# Altium schematic sheet Matching_Capacitors.SchDoc(Matching_Capacitors)
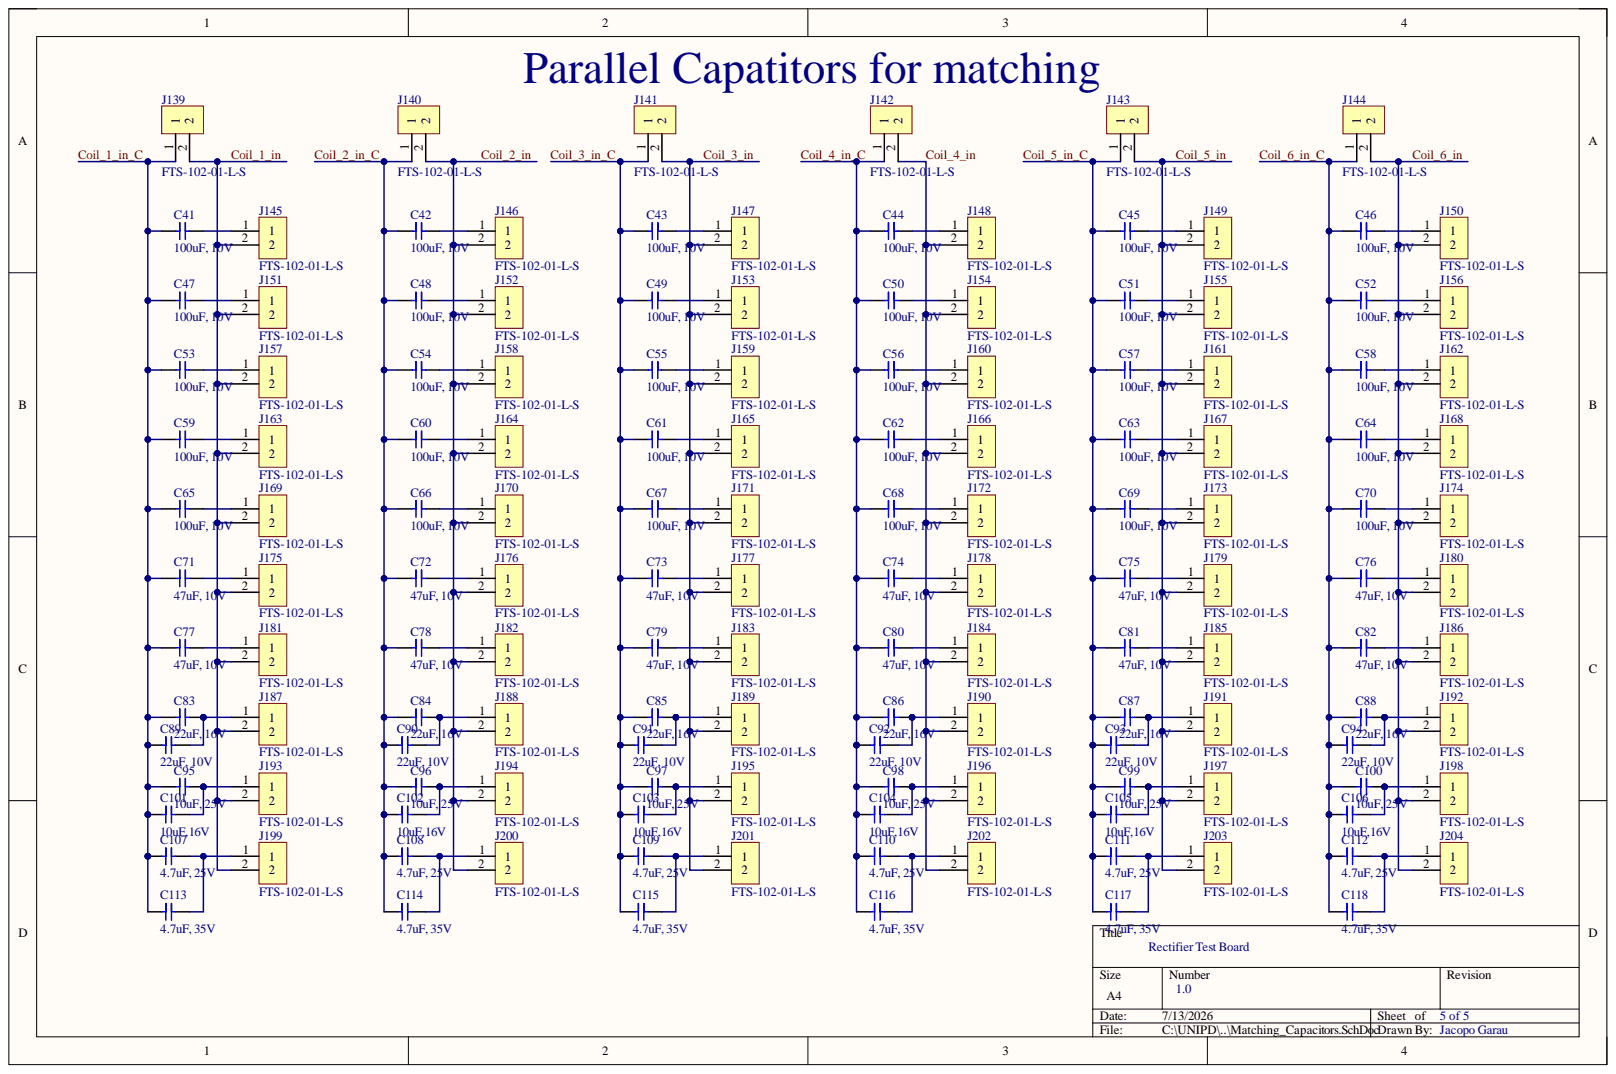
[diagram p0: Matching_Capacitors.SchDoc]

## Parts
C41  100uF, 10V  [CAP_1206]
C42  100uF, 10V  [CAP_1206]
C43  100uF, 10V  [CAP_1206]
C44  100uF, 10V  [CAP_1206]
C45  100uF, 10V  [CAP_1206]
C46  100uF, 10V  [CAP_1206]
C47  100uF, 10V  [CAP_1206]
C48  100uF, 10V  [CAP_1206]
C49  100uF, 10V  [CAP_1206]
C50  100uF, 10V  [CAP_1206]
C51  100uF, 10V  [CAP_1206]
C52  100uF, 10V  [CAP_1206]
C53  100uF, 10V  [CAP_1206]
C54  100uF, 10V  [CAP_1206]
C55  100uF, 10V  [CAP_1206]
C56  100uF, 10V  [CAP_1206]
C57  100uF, 10V  [CAP_1206]
C58  100uF, 10V  [CAP_1206]
C59  100uF, 10V  [CAP_1206]
C60  100uF, 10V  [CAP_1206]
C61  100uF, 10V  [CAP_1206]
C62  100uF, 10V  [CAP_1206]
C63  100uF, 10V  [CAP_1206]
C64  100uF, 10V  [CAP_1206]
C65  100uF, 10V  [CAP_1206]
C66  100uF, 10V  [CAP_1206]
C67  100uF, 10V  [CAP_1206]
C68  100uF, 10V  [CAP_1206]
C69  100uF, 10V  [CAP_1206]
C70  100uF, 10V  [CAP_1206]
C71  47uF, 10V  Voltage: 10V  [CAP_1206]
C72  47uF, 10V  Voltage: 10V  [CAP_1206]
C73  47uF, 10V  Voltage: 10V  [CAP_1206]
C74  47uF, 10V  Voltage: 10V  [CAP_1206]
C75  47uF, 10V  Voltage: 10V  [CAP_1206]
C76  47uF, 10V  Voltage: 10V  [CAP_1206]
C77  47uF, 10V  Voltage: 10V  [CAP_1206]
C78  47uF, 10V  Voltage: 10V  [CAP_1206]
C79  47uF, 10V  Voltage: 10V  [CAP_1206]
C80  47uF, 10V  Voltage: 10V  [CAP_1206]
C81  47uF, 10V  Voltage: 10V  [CAP_1206]
C82  47uF, 10V  Voltage: 10V  [CAP_1206]
C83  22uF, 16V  Voltage: 16V  [CAP_1206]
C84  22uF, 16V  Voltage: 16V  [CAP_1206]
C85  22uF, 16V  Voltage: 16V  [CAP_1206]
C86  Voltage: 16V  [CAP_1206]  (hidden comment: 22uF, 16V)
C87  22uF, 16V  Voltage: 16V  [CAP_1206]
C88  22uF, 16V  Voltage: 16V  [CAP_1206]
C89  22uF, 10V  Voltage Rating: 10V  [CAP_0805]
C90  22uF, 10V  Voltage Rating: 10V  [CAP_0805]
C91  22uF, 10V  Voltage Rating: 10V  [CAP_0805]
C92  22uF, 10V  Voltage Rating: 10V  [CAP_0805]
C93  22uF, 10V  Voltage Rating: 10V  [CAP_0805]
C94  22uF, 10V  Voltage Rating: 10V  [CAP_0805]
C95  10uF, 25V  Voltage: 25V  [CAP_1206]
C96  10uF, 25V  Voltage: 25V  [CAP_1206]
C97  10uF, 25V  Voltage: 25V  [CAP_1206]
C98  10uF, 25V  Voltage: 25V  [CAP_1206]
C99  10uF, 25V  Voltage: 25V  [CAP_1206]
C100  10uF, 25V  Voltage: 25V  [CAP_1206]
C101  10uF,16V  [CAP_0805]
C102  10uF,16V  [CAP_0805]
C103  10uF,16V  [CAP_0805]
C104  10uF,16V  [CAP_0805]
C105  10uF,16V  [CAP_0805]
C106  10uF,16V  [CAP_0805]
C107  4.7uF, 25V  Voltage: 25V  [CAP_1206]
C108  4.7uF, 25V  Voltage: 25V  [CAP_1206]
C109  4.7uF, 25V  Voltage: 25V  [CAP_1206]
C110  4.7uF, 25V  Voltage: 25V  [CAP_1206]
C111  4.7uF, 25V  Voltage: 25V  [CAP_1206]
C112  4.7uF, 25V  Voltage: 25V  [CAP_1206]
C113  4.7uF, 35V  Voltage Rating: 35V  [CAP_0805]
C114  4.7uF, 35V  Voltage Rating: 35V  [CAP_0805]
C115  4.7uF, 35V  Voltage Rating: 35V  [CAP_0805]
C116  4.7uF, 35V  Voltage Rating: 35V  [CAP_0805]
C117  4.7uF, 35V  Voltage Rating: 35V  [CAP_0805]
C118  4.7uF, 35V  Voltage Rating: 35V  [CAP_0805]
J139  FTS-102-01-L-S  [HDR_1x2_1.27mm]
J140  FTS-102-01-L-S  [HDR_1x2_1.27mm]
J141  FTS-102-01-L-S  [HDR_1x2_1.27mm]
J142  FTS-102-01-L-S  [HDR_1x2_1.27mm]
J143  FTS-102-01-L-S  [HDR_1x2_1.27mm]
J144  FTS-102-01-L-S  [HDR_1x2_1.27mm]
J145  FTS-102-01-L-S  [HDR_1x2_1.27mm]
J146  FTS-102-01-L-S  [HDR_1x2_1.27mm]
J147  FTS-102-01-L-S  [HDR_1x2_1.27mm]
J148  FTS-102-01-L-S  [HDR_1x2_1.27mm]
J149  FTS-102-01-L-S  [HDR_1x2_1.27mm]
J150  FTS-102-01-L-S  [HDR_1x2_1.27mm]
J151  FTS-102-01-L-S  [HDR_1x2_1.27mm]
J152  FTS-102-01-L-S  [HDR_1x2_1.27mm]
J153  FTS-102-01-L-S  [HDR_1x2_1.27mm]
J154  FTS-102-01-L-S  [HDR_1x2_1.27mm]
J155  FTS-102-01-L-S  [HDR_1x2_1.27mm]
J156  FTS-102-01-L-S  [HDR_1x2_1.27mm]
J157  FTS-102-01-L-S  [HDR_1x2_1.27mm]
J158  FTS-102-01-L-S  [HDR_1x2_1.27mm]
J159  FTS-102-01-L-S  [HDR_1x2_1.27mm]
J160  FTS-102-01-L-S  [HDR_1x2_1.27mm]
J161  FTS-102-01-L-S  [HDR_1x2_1.27mm]
J162  FTS-102-01-L-S  [HDR_1x2_1.27mm]
J163  FTS-102-01-L-S  [HDR_1x2_1.27mm]
J164  FTS-102-01-L-S  [HDR_1x2_1.27mm]
J165  FTS-102-01-L-S  [HDR_1x2_1.27mm]
J166  FTS-102-01-L-S  [HDR_1x2_1.27mm]
J167  FTS-102-01-L-S  [HDR_1x2_1.27mm]
J168  FTS-102-01-L-S  [HDR_1x2_1.27mm]
J169  FTS-102-01-L-S  [HDR_1x2_1.27mm]
J170  FTS-102-01-L-S  [HDR_1x2_1.27mm]
J171  FTS-102-01-L-S  [HDR_1x2_1.27mm]
J172  FTS-102-01-L-S  [HDR_1x2_1.27mm]
J173  FTS-102-01-L-S  [HDR_1x2_1.27mm]
J174  FTS-102-01-L-S  [HDR_1x2_1.27mm]
J175  FTS-102-01-L-S  [HDR_1x2_1.27mm]
J176  FTS-102-01-L-S  [HDR_1x2_1.27mm]
J177  FTS-102-01-L-S  [HDR_1x2_1.27mm]
J178  FTS-102-01-L-S  [HDR_1x2_1.27mm]
J179  FTS-102-01-L-S  [HDR_1x2_1.27mm]
J180  FTS-102-01-L-S  [HDR_1x2_1.27mm]
J181  FTS-102-01-L-S  [HDR_1x2_1.27mm]
J182  FTS-102-01-L-S  [HDR_1x2_1.27mm]
J183  FTS-102-01-L-S  [HDR_1x2_1.27mm]
J184  FTS-102-01-L-S  [HDR_1x2_1.27mm]
J185  FTS-102-01-L-S  [HDR_1x2_1.27mm]
J186  FTS-102-01-L-S  [HDR_1x2_1.27mm]
J187  FTS-102-01-L-S  [HDR_1x2_1.27mm]
J188  FTS-102-01-L-S  [HDR_1x2_1.27mm]
J189  FTS-102-01-L-S  [HDR_1x2_1.27mm]
J190  FTS-102-01-L-S  [HDR_1x2_1.27mm]
J191  FTS-102-01-L-S  [HDR_1x2_1.27mm]
J192  FTS-102-01-L-S  [HDR_1x2_1.27mm]
J193  FTS-102-01-L-S  [HDR_1x2_1.27mm]
J194  FTS-102-01-L-S  [HDR_1x2_1.27mm]
J195  FTS-102-01-L-S  [HDR_1x2_1.27mm]
J196  FTS-102-01-L-S  [HDR_1x2_1.27mm]
J197  FTS-102-01-L-S  [HDR_1x2_1.27mm]
J198  FTS-102-01-L-S  [HDR_1x2_1.27mm]
J199  FTS-102-01-L-S  [HDR_1x2_1.27mm]
J200  FTS-102-01-L-S  [HDR_1x2_1.27mm]
J201  FTS-102-01-L-S  [HDR_1x2_1.27mm]
J202  FTS-102-01-L-S  [HDR_1x2_1.27mm]
J203  FTS-102-01-L-S  [HDR_1x2_1.27mm]
J204  FTS-102-01-L-S  [HDR_1x2_1.27mm]

## Nets
Coil_1_in: J139.2 J145.2 J151.2 J157.2 J163.2 J169.2 J175.2 J181.2 J187.2 J193.2 J199.2
Coil_1_in_C: C41.1 C47.1 C53.1 C59.1 C65.1 C71.1 C77.1 C83.1 C89.1 C95.1 C101.1 C107.1 C113.1 J139.1
Coil_2_in: J140.2 J146.2 J152.2 J158.2 J164.2 J170.2 J176.2 J182.2 J188.2 J194.2 J200.2
Coil_2_in_C: C42.1 C48.1 C54.1 C60.1 C66.1 C72.1 C78.1 C84.1 C90.1 C96.1 C102.1 C108.1 C114.1 J140.1
Coil_3_in: J141.2 J147.2 J153.2 J159.2 J165.2 J171.2 J177.2 J183.2 J189.2 J195.2 J201.2
Coil_3_in_C: C43.1 C49.1 C55.1 C61.1 C67.1 C73.1 C79.1 C85.1 C91.1 C97.1 C103.1 C109.1 C115.1 J141.1
Coil_4_in: J142.2 J148.2 J154.2 J160.2 J166.2 J172.2 J178.2 J184.2 J190.2 J196.2 J202.2
Coil_4_in_C: C44.1 C50.1 C56.1 C62.1 C68.1 C74.1 C80.1 C86.1 C92.1 C98.1 C104.1 C110.1 C116.1 J142.1
Coil_5_in: J143.2 J149.2 J155.2 J161.2 J167.2 J173.2 J179.2 J185.2 J191.2 J197.2 J203.2
Coil_5_in_C: C45.1 C51.1 C57.1 C63.1 C69.1 C75.1 C81.1 C87.1 C93.1 C99.1 C105.1 C111.1 C117.1 J143.1
Coil_6_in: J144.2 J150.2 J156.2 J162.2 J168.2 J174.2 J180.2 J186.2 J192.2 J198.2 J204.2
Coil_6_in_C: C46.1 C52.1 C58.1 C64.1 C70.1 C76.1 C82.1 C88.1 C94.1 C100.1 C106.1 C112.1 C118.1 J144.1
NetC41_2: C41.2 J145.1
NetC42_2: C42.2 J146.1
NetC43_2: C43.2 J147.1
NetC44_2: C44.2 J148.1
NetC45_2: C45.2 J149.1
NetC46_2: C46.2 J150.1
NetC47_2: C47.2 J151.1
NetC48_2: C48.2 J152.1
NetC49_2: C49.2 J153.1
NetC50_2: C50.2 J154.1
NetC51_2: C51.2 J155.1
NetC52_2: C52.2 J156.1
NetC53_2: C53.2 J157.1
NetC54_2: C54.2 J158.1
NetC55_2: C55.2 J159.1
NetC56_2: C56.2 J160.1
NetC57_2: C57.2 J161.1
NetC58_2: C58.2 J162.1
NetC59_2: C59.2 J163.1
NetC60_2: C60.2 J164.1
NetC61_2: C61.2 J165.1
NetC62_2: C62.2 J166.1
NetC63_2: C63.2 J167.1
NetC64_2: C64.2 J168.1
NetC65_2: C65.2 J169.1
NetC66_2: C66.2 J170.1
NetC67_2: C67.2 J171.1
NetC68_2: C68.2 J172.1
NetC69_2: C69.2 J173.1
NetC70_2: C70.2 J174.1
NetC71_2: C71.2 J175.1
NetC72_2: C72.2 J176.1
NetC73_2: C73.2 J177.1
NetC74_2: C74.2 J178.1
NetC75_2: C75.2 J179.1
NetC76_2: C76.2 J180.1
NetC77_2: C77.2 J181.1
NetC78_2: C78.2 J182.1
NetC79_2: C79.2 J183.1
NetC80_2: C80.2 J184.1
NetC81_2: C81.2 J185.1
NetC82_2: C82.2 J186.1
NetC83_2: C83.2 C89.2 J187.1
NetC84_2: C84.2 C90.2 J188.1
NetC85_2: C85.2 C91.2 J189.1
NetC86_2: C86.2 C92.2 J190.1
NetC87_2: C87.2 C93.2 J191.1
NetC88_2: C88.2 C94.2 J192.1
NetC95_2: C95.2 C101.2 J193.1
NetC96_2: C96.2 C102.2 J194.1
NetC97_2: C97.2 C103.2 J195.1
NetC98_2: C98.2 C104.2 J196.1
NetC99_2: C99.2 C105.2 J197.1
NetC100_2: C100.2 C106.2 J198.1
NetC107_2: C107.2 C113.2 J199.1
NetC108_2: C108.2 C114.2 J200.1
NetC109_2: C109.2 C115.2 J201.1
NetC110_2: C110.2 C116.2 J202.1
NetC111_2: C111.2 C117.2 J203.1
NetC112_2: C112.2 C118.2 J204.1

## Library data of the parts
C41-C70 = GRM31CR61A107MEA8L: 10V 100uF X5R ±20% 1206 Multilayer Ceramic Capacitors MLCC - SMD/SMT ROHS; Manufacturer: Murata; Manufacturer Lifecycle Status: IN PRODUCTION; Value: 100µF; Case/Package: 1206; Category: Ceramic Capacitors; Component Kind: Standard; ComponentLink1Description: https://datasheet.octopart.com:443/GRM31CR61A107MEA8L-Murata-datasheet-165579666.pdf?src-supplier=TME; ComponentLink1URL: https://datasheet.octopart.com:443/GRM31CR61A107MEA8L-Murata-datasheet-165579666.pdf?src-supplier=TME; ComponentLink2Description: https://datasheet.octopart.com/GRM31CR61A107MEA8L-Murata-datasheet-12515295.pdf; ComponentLink2URL: https://datasheet.octopart.com/GRM31CR61A107MEA8L-Murata-datasheet-12515295.pdf; ComponentLink3Description: https://datasheet.octopart.com/GRM31CR61A107MEA8L-Murata-datasheet-12510076.pdf; ComponentLink3URL: https://datasheet.octopart.com/GRM31CR61A107MEA8L-Murata-datasheet-12510076.pdf; ComponentLink4Description: https://datasheet.octopart.com/GRM31CR61A107MEA8L-Murata-datasheet-11978611.pdf; ComponentLink4URL: https://datasheet.octopart.com/GRM31CR61A107MEA8L-Murata-datasheet-11978611.pdf; ComponentLink5Description: https://datasheet.octopart.com/GRM31CR61A107MEA8L-Murata-datasheet-10025737.pdf; ComponentLink5URL: https://datasheet.octopart.com/GRM31CR61A107MEA8L-Murata-datasheet-10025737.pdf; ComponentLink6Description: https://datasheet.octopart.com/GRM31CR61A107MEA8L-Murata-datasheet-8819587.pdf; ComponentLink6URL: https://datasheet.octopart.com/GRM31CR61A107MEA8L-Murata-datasheet-8819587.pdf; ComponentLink7Description: http://sigma.octopart.com:80/reach_statement/Murata-company-16.pdf?src-supplier=Compliance+Statements; ComponentLink7URL: http://sigma.octopart.com:80/reach_statement/Murata-company-16.pdf?src-supplier=Compliance+Statements; Dielectric: X5R; Dielectric Material: Ceramic; Export Control Classification Number (ECCN) Code: EAR99; Harmonized Tariff Schedule (HTS) Code: 8532.24.00.20; Height: 1.6mm; Introduction Date: 2020-12-28; Length: 3.2mm; Library Name: My_Integrated_Library.IntLib; Lifecycle Status: Production; Manufacturer Part Number: GRM31CR61A107MEA8L; Material: Ceramic; Max Operating Temperature: 85°C; Min Operating Temperature: -55°C; Mounting Technology: Surface Mount; Number of Terminals: 2; Pricing: 1=0.49, 10=0.3, 50=0.2244, 100=0.2009, 500=0.16148, 1000=0.14931 (USD); REACH SVHC: Yes; RoHS: Compliant; Stock: 193494; Supplier: DigiKey; Supplier Part Number: 490-GRM31CR61A107MEA8LDKR-ND; Thickness: 1.6mm; Tolerance: 20%; Voltage Rating: 10V; Voltage Rating (DC): 10V; Width: 1.6mm
C71-C82 = GRM31CR61A476KE15L: 10V 47uF X5R ±10% 1206 Multilayer Ceramic Capacitors MLCC - SMD/SMT ROHS; Manufacturer: Murata; Manufacturer Lifecycle Status: IN PRODUCTION; Value: 47µF; Case Code (Imperial): 1206; Case Code (Metric): 3216; Case/Package: 1206; Category: Ceramic Capacitors; Component Kind: Standard; ComponentLink1Description: https://datasheet.octopart.com:443/GRM31CR61A476KE15L-Murata-datasheet-27203393.pdf?src-supplier=Newark; ComponentLink1URL: https://datasheet.octopart.com:443/GRM31CR61A476KE15L-Murata-datasheet-27203393.pdf?src-supplier=Newark; ComponentLink2Description: https://datasheet.octopart.com:443/GRM31CR61A476KE15L-Murata-datasheet-62317354.pdf?src-supplier=element14+APAC; ComponentLink2URL: https://datasheet.octopart.com:443/GRM31CR61A476KE15L-Murata-datasheet-62317354.pdf?src-supplier=element14+APAC; ComponentLink3Description: https://datasheet.octopart.com:443/GRM31CR61A476KE15L-Murata-datasheet-14402797.pdf?src-supplier=Future+Electronics; ComponentLink3URL: https://datasheet.octopart.com:443/GRM31CR61A476KE15L-Murata-datasheet-14402797.pdf?src-supplier=Future+Electronics; ComponentLink4Description: https://datasheet.octopart.com:443/GRM31CR61A476KE15L-Murata-datasheet-22122223.pdf?src-supplier=Future+Electronics; ComponentLink4URL: https://datasheet.octopart.com:443/GRM31CR61A476KE15L-Murata-datasheet-22122223.pdf?src-supplier=Future+Electronics; ComponentLink5Description: https://datasheet.octopart.com:443/GRM31CR61A476KE15L-Murata-datasheet-44109370.pdf?src-supplier=Future+Electronics; ComponentLink5URL: https://datasheet.octopart.com:443/GRM31CR61A476KE15L-Murata-datasheet-44109370.pdf?src-supplier=Future+Electronics; ComponentLink6Description: https://datasheet.octopart.com:443/GRM31CR61A476KE15L-Murata-datasheet-165579666.pdf?src-supplier=TME; ComponentLink6URL: https://datasheet.octopart.com:443/GRM31CR61A476KE15L-Murata-datasheet-165579666.pdf?src-supplier=TME; ComponentLink7Description: https://datasheet.octopart.com:443/GRM31CR61A476KE15L-Murata-datasheet-140709096.pdf?src-supplier=Ciiva; ComponentLink7URL: https://datasheet.octopart.com:443/GRM31CR61A476KE15L-Murata-datasheet-140709096.pdf?src-supplier=Ciiva; ComponentLink8Description: https://datasheet.octopart.com:443/GRM31CR61A476KE15L-Murata-datasheet-138746346.pdf?src-supplier=Ciiva; ComponentLink8URL: https://datasheet.octopart.com:443/GRM31CR61A476KE15L-Murata-datasheet-138746346.pdf?src-supplier=Ciiva; ComponentLink9Description: https://datasheet.octopart.com:443/GRM31CR61A476KE15L-Murata-datasheet-102370999.pdf?src-supplier=HQonline; ComponentLink9URL: https://datasheet.octopart.com:443/GRM31CR61A476KE15L-Murata-datasheet-102370999.pdf?src-supplier=HQonline; ComponentLink10Description: https://datasheet.octopart.com/GRM31CR61A476KE15L-Murata-datasheet-12515295.pdf; ComponentLink10URL: https://datasheet.octopart.com/GRM31CR61A476KE15L-Murata-datasheet-12515295.pdf; ComponentLink11Description: https://datasheet.octopart.com/GRM31CR61A476KE15L-Murata-datasheet-12510076.pdf; ComponentLink11URL: https://datasheet.octopart.com/GRM31CR61A476KE15L-Murata-datasheet-12510076.pdf; ComponentLink12Description: https://datasheet.octopart.com/GRM31CR61A476KE15L-Murata-datasheet-11978611.pdf; ComponentLink12URL: https://datasheet.octopart.com/GRM31CR61A476KE15L-Murata-datasheet-11978611.pdf; ComponentLink13Description: https://datasheet.octopart.com/GRM31CR61A476KE15L-Murata-datasheet-10025737.pdf; ComponentLink13URL: https://datasheet.octopart.com/GRM31CR61A476KE15L-Murata-datasheet-10025737.pdf; ComponentLink14Description: https://datasheet.octopart.com/GRM31CR61A476KE15L-Murata-datasheet-8819587.pdf; ComponentLink14URL: https://datasheet.octopart.com/GRM31CR61A476KE15L-Murata-datasheet-8819587.pdf; ComponentLink15Description: https://datasheet.octopart.com:443/GRM31CR61A476KE15L-Murata-datasheet-150839329.pdf?src-supplier=Arrow.cn; ComponentLink15URL: https://datasheet.octopart.com:443/GRM31CR61A476KE15L-Murata-datasheet-150839329.pdf?src-supplier=Arrow.cn; ComponentLink16Description: http://sigma.octopart.com:80/reach_statement/Murata-company-16.pdf?src-supplier=Compliance+Statements; ComponentLink16URL: http://sigma.octopart.com:80/reach_statement/Murata-company-16.pdf?src-supplier=Compliance+Statements; Country of Origin: Japan, Mainland China, Philippines, Singapore, Thailand; Depth: 1.6mm; Dielectric: X5R; Dielectric Material: Ceramic; Export Control Classification Number (ECCN) Code: EAR99; Harmonized Tariff Schedule (HTS) Code: 8532.24.00.20; Height: 1.6mm; Introduction Date: 2010-04-15; Lead Free: Lead Free; Length: 3.2mm; Library Name: My_Integrated_Library.IntLib; Lifecycle Status: Production; Manufacturer Part Number: GRM31CR61A476KE15L; Material: Ceramic; Max Operating Temperature: 85°C; Min Operating Temperature: -55°C; Mounting Technology: Surface Mount; Number of Terminals: 2; Packaging: Cut Tape; Pricing: 1=0.4, 10=0.243, 50=0.1792, 100=0.1597, 500=0.12692, 1000=0.11678 (USD); REACH SVHC: Yes; RoHS: Compliant; Schedule B: 8532240020, 8532240020|8532240020, 8532240020|8532240020|8532240020, 8532240020|8532240020|8532240020|8532240020; Stock: 83216; Supplier: DigiKey; Supplier Part Number: 490-9673-1-ND; Termination: SMD/SMT; Thickness: 1.6mm; Tolerance: 10%; Voltage Rating: 10V; Voltage Rating (DC): 10V; Width: 1.6mm
C83-C88 = CL31A226MOCLNNC: 16V 22uF X5R 卤20% 1206 Multilayer Ceramic Capacitors MLCC - SMD/SMT ROHS; Manufacturer: Samsung Electro-Mechanics; Tolerance: 20%; Value: 22µF; Case Code (Imperial): 1206; Case Code (Metric): 3216; Case/Package: 1206; Category: Ceramic Capacitors; China RoHS: Compliant; Component Kind: Standard; ComponentLink1Description: https://datasheet.octopart.com:443/CL31A226MOCLNNC-Samsung-Electro-Mechanics-datasheet-136031855.pdf?src-supplier=Newark; ComponentLink1URL: https://datasheet.octopart.com:443/CL31A226MOCLNNC-Samsung-Electro-Mechanics-datasheet-136031855.pdf?src-supplier=Newark; ComponentLink2Description: https://datasheet.octopart.com:443/CL31A226MOCLNNC-Samsung-Electro-Mechanics-datasheet-137700063.pdf?src-supplier=Newark; ComponentLink2URL: https://datasheet.octopart.com:443/CL31A226MOCLNNC-Samsung-Electro-Mechanics-datasheet-137700063.pdf?src-supplier=Newark; ComponentLink3Description: https://datasheet.octopart.com:443/CL31A226MOCLNNC-Samsung-Electro-Mechanics-datasheet-149066833.pdf?src-supplier=Future+Electronics; ComponentLink3URL: https://datasheet.octopart.com:443/CL31A226MOCLNNC-Samsung-Electro-Mechanics-datasheet-149066833.pdf?src-supplier=Future+Electronics; ComponentLink4Description: https://datasheet.octopart.com:443/CL31A226MOCLNNC-Samsung-Electro-Mechanics-datasheet-110365223.pdf?src-supplier=Future+Electronics; ComponentLink4URL: https://datasheet.octopart.com:443/CL31A226MOCLNNC-Samsung-Electro-Mechanics-datasheet-110365223.pdf?src-supplier=Future+Electronics; ComponentLink5Description: https://datasheet.octopart.com:443/CL31A226MOCLNNC-Samsung-Electro-Mechanics-datasheet-81462439.pdf?src-supplier=Future+Electronics; ComponentLink5URL: https://datasheet.octopart.com:443/CL31A226MOCLNNC-Samsung-Electro-Mechanics-datasheet-81462439.pdf?src-supplier=Future+Electronics; ComponentLink6Description: https://datasheet.octopart.com:443/CL31A226MOCLNNC-Samsung-datasheet-21165136.pdf?src-supplier=Future+Electronics; ComponentLink6URL: https://datasheet.octopart.com:443/CL31A226MOCLNNC-Samsung-datasheet-21165136.pdf?src-supplier=Future+Electronics; ComponentLink7Description: https://datasheet.octopart.com:443/CL31A226MOCLNNC-Samsung-datasheet-26669498.pdf?src-supplier=Future+Electronics; ComponentLink7URL: https://datasheet.octopart.com:443/CL31A226MOCLNNC-Samsung-datasheet-26669498.pdf?src-supplier=Future+Electronics; ComponentLink8Description: https://datasheet.octopart.com:443/CL31A226MOCLNNC-Samsung-datasheet-37081328.pdf?src-supplier=Future+Electronics; ComponentLink8URL: https://datasheet.octopart.com:443/CL31A226MOCLNNC-Samsung-datasheet-37081328.pdf?src-supplier=Future+Electronics; ComponentLink9Description: https://datasheet.octopart.com/CL31A226MOCLNNC-Samsung-Electro-Mechanics-datasheet-97040617.pdf; ComponentLink9URL: https://datasheet.octopart.com/CL31A226MOCLNNC-Samsung-Electro-Mechanics-datasheet-97040617.pdf; ComponentLink10Description: https://datasheet.octopart.com:443/CL31A226MOCLNNC-Samsung-datasheet-59220082.pdf?src-supplier=TME; ComponentLink10URL: https://datasheet.octopart.com:443/CL31A226MOCLNNC-Samsung-datasheet-59220082.pdf?src-supplier=TME; Depth: 1.6mm; Dielectric: X5R; Dielectric Material: Ceramic; Height: 1.4mm; Lead Free: Lead Free; Length: 3.2mm; Library Name: My_Integrated_Library.IntLib; Lifecycle Status: Production; Manufacturer Part Number: CL31A226MOCLNNC; Material: Ceramic; Max Operating Temperature: 85°C; Min Operating Temperature: -55°C; Mounting Technology: Surface Mount; Number of Terminals: 2; Packaging: Tape & Reel; Pricing: 1=0.25, 10=0.142, 50=0.1018, 100=0.0896, 500=0.06904, 1000=0.0627 (USD); Radiation Hardening: No; REACH SVHC: Yes; RoHS: Compliant; Schedule B: 8532240020, 8532240020|8532240020, 8532240020|8532240020|8532240020, 8532240020|8532240020|8532240020|8532240020; Stock: 351963; Supplier: DigiKey; Supplier Part Number: 1276-2728-1-ND; Thickness: 939.8µm; Voltage Rating: 16V; Voltage Rating (DC): 16V; Width: 1.6002mm
C89-C94 = CL21A226MPQNNNE: 10V 22uF X5R 卤20% 0805 Multilayer Ceramic Capacitors MLCC - SMD/SMT ROHS; Manufacturer: Samsung Electro-Mechanics; Manufacturer Package Identifier: 0805 (2012 metric); Tolerance: 20%; Value: 22µF; Case Code (Imperial): 0805; Case Code (Metric): 2012; Case/Package: 0805; Category: Ceramic Capacitors; China RoHS: Compliant; Component Kind: Standard; ComponentLink1Description: https://datasheet.octopart.com:443/CL21A226MPQNNNE-Samsung-Electro-Mechanics-datasheet-136322592.pdf?src-supplier=Newark; ComponentLink1URL: https://datasheet.octopart.com:443/CL21A226MPQNNNE-Samsung-Electro-Mechanics-datasheet-136322592.pdf?src-supplier=Newark; ComponentLink2Description: https://datasheet.octopart.com:443/CL21A226MPQNNNE-Samsung-Electro-Mechanics-datasheet-81462142.pdf?src-supplier=Upverter; ComponentLink2URL: https://datasheet.octopart.com:443/CL21A226MPQNNNE-Samsung-Electro-Mechanics-datasheet-81462142.pdf?src-supplier=Upverter; ComponentLink3Description: https://datasheet.octopart.com:443/CL21A226MPQNNNE-Samsung-Electro-Mechanics-datasheet-110333974.pdf?src-supplier=Future+Electronics; ComponentLink3URL: https://datasheet.octopart.com:443/CL21A226MPQNNNE-Samsung-Electro-Mechanics-datasheet-110333974.pdf?src-supplier=Future+Electronics; ComponentLink4Description: https://datasheet.octopart.com:443/CL21A226MPQNNNE-Samsung-datasheet-22123520.pdf?src-supplier=Future+Electronics; ComponentLink4URL: https://datasheet.octopart.com:443/CL21A226MPQNNNE-Samsung-datasheet-22123520.pdf?src-supplier=Future+Electronics; ComponentLink5Description: https://datasheet.octopart.com:443/CL21A226MPQNNNE-Samsung-Electro-Mechanics-datasheet-149154245.pdf?src-supplier=Future+Electronics; ComponentLink5URL: https://datasheet.octopart.com:443/CL21A226MPQNNNE-Samsung-Electro-Mechanics-datasheet-149154245.pdf?src-supplier=Future+Electronics; ComponentLink6Description: https://datasheet.octopart.com:443/CL21A226MPQNNNE-Samsung-datasheet-37081328.pdf?src-supplier=Future+Electronics; ComponentLink6URL: https://datasheet.octopart.com:443/CL21A226MPQNNNE-Samsung-datasheet-37081328.pdf?src-supplier=Future+Electronics; ComponentLink7Description: https://datasheet.octopart.com/CL21A226MPQNNNE-Samsung-Electro-Mechanics-datasheet-97040617.pdf; ComponentLink7URL: https://datasheet.octopart.com/CL21A226MPQNNNE-Samsung-Electro-Mechanics-datasheet-97040617.pdf; ComponentLink8Description: https://datasheet.octopart.com/CL21A226MPQNNNE-Samsung-Electro-Mechanics-datasheet-8324245.pdf; ComponentLink8URL: https://datasheet.octopart.com/CL21A226MPQNNNE-Samsung-Electro-Mechanics-datasheet-8324245.pdf; ComponentLink9Description: https://datasheet.octopart.com:443/CL21A226MPQNNNE-Samsung-datasheet-8326407.pdf?src-supplier=TME; ComponentLink9URL: https://datasheet.octopart.com:443/CL21A226MPQNNNE-Samsung-datasheet-8326407.pdf?src-supplier=TME; Country of Origin: Mainland China, Philippines, South Korea; Dielectric: X5R; Dielectric Material: Ceramic; Export Control Classification Number (ECCN) Code: EAR99; Harmonized Tariff Schedule (HTS) Code: 8532.24.00.20; Height: 1.4mm; Introduction Date: 2010-07-30; Lead Free: Lead Free; Length: 2mm; Library Name: My_Integrated_Library.IntLib; Lifecycle Status: Production; Manufacturer Part Number: CL21A226MPQNNNE; Max Operating Temperature: 85°C; Min Operating Temperature: -55°C; Mounting Technology: Surface Mount; Number of Terminals: 2; Packaging: Tape & Reel (TR); Pricing: 1=0.21, 10=0.119, 50=0.085, 100=0.0745, 500=0.05682, 1000=0.05138 (USD); REACH SVHC: Yes; RoHS: Compliant; Schedule B: 8532240020, 8532240020|8532240020|8532240020|8532240020|8532240020|8532240020|8532240020|8532240020|8532240020|8532240020|8532240020|8532240020|8532240020|8532240020|8532240020|8532240020|8532240020|8532240020|8532240020|8532240020|8532240020|8532240020|8532240020|85; Stock: 14176; Supplier: DigiKey; Supplier Part Number: 1276-2910-1-ND; Thickness: 1.3462mm; Voltage Rating (DC): 10V; Width: 1.25mm
C95-C100 = CL31A106KAHNNNE: 25V 10uF X5R 卤10% 1206 Multilayer Ceramic Capacitors MLCC - SMD/SMT ROHS; Manufacturer: Samsung Electro-Mechanics; Tolerance: 10%; Value: 10µF; Case Code (Imperial): 1206; Case Code (Metric): 3216; Case/Package: 1206; Category: Ceramic Capacitors; China RoHS: Compliant; Component Kind: Standard; ComponentLink1Description: https://datasheet.octopart.com:443/CL31A106KAHNNNE-Samsung-Electro-Mechanics-datasheet-182024200.pdf?src-supplier=IHS; ComponentLink1URL: https://datasheet.octopart.com:443/CL31A106KAHNNNE-Samsung-Electro-Mechanics-datasheet-182024200.pdf?src-supplier=IHS; ComponentLink2Description: https://datasheet.octopart.com:443/CL31A106KAHNNNE-Samsung-Electro-Mechanics-datasheet-136031842.pdf?src-supplier=Samsung+SCT; ComponentLink2URL: https://datasheet.octopart.com:443/CL31A106KAHNNNE-Samsung-Electro-Mechanics-datasheet-136031842.pdf?src-supplier=Samsung+SCT; ComponentLink3Description: https://datasheet.octopart.com:443/CL31A106KAHNNNE-Samsung-Electro-Mechanics-datasheet-11805919.pdf?src-supplier=Future+Electronics; ComponentLink3URL: https://datasheet.octopart.com:443/CL31A106KAHNNNE-Samsung-Electro-Mechanics-datasheet-11805919.pdf?src-supplier=Future+Electronics; ComponentLink4Description: https://datasheet.octopart.com:443/CL31A106KAHNNNE-Samsung-Electro-Mechanics-datasheet-149066807.pdf?src-supplier=Future+Electronics; ComponentLink4URL: https://datasheet.octopart.com:443/CL31A106KAHNNNE-Samsung-Electro-Mechanics-datasheet-149066807.pdf?src-supplier=Future+Electronics; ComponentLink5Description: https://datasheet.octopart.com:443/CL31A106KAHNNNE-Samsung-Electro-Mechanics-datasheet-110365189.pdf?src-supplier=Future+Electronics; ComponentLink5URL: https://datasheet.octopart.com:443/CL31A106KAHNNNE-Samsung-Electro-Mechanics-datasheet-110365189.pdf?src-supplier=Future+Electronics; ComponentLink6Description: https://datasheet.octopart.com:443/CL31A106KAHNNNE-Samsung-Electro-Mechanics-datasheet-95631919.pdf?src-supplier=Future+Electronics; ComponentLink6URL: https://datasheet.octopart.com:443/CL31A106KAHNNNE-Samsung-Electro-Mechanics-datasheet-95631919.pdf?src-supplier=Future+Electronics; ComponentLink7Description: https://datasheet.octopart.com:443/CL31A106KAHNNNE-Samsung-datasheet-42315306.pdf?src-supplier=Future+Electronics; ComponentLink7URL: https://datasheet.octopart.com:443/CL31A106KAHNNNE-Samsung-datasheet-42315306.pdf?src-supplier=Future+Electronics; ComponentLink8Description: https://datasheet.octopart.com/CL31A106KAHNNNE-Samsung-Electro-Mechanics-datasheet-8324245.pdf; ComponentLink8URL: https://datasheet.octopart.com/CL31A106KAHNNNE-Samsung-Electro-Mechanics-datasheet-8324245.pdf; ComponentLink9Description: https://datasheet.octopart.com/CL31A106KAHNNNE-Samsung-Electro-Mechanics-datasheet-97040617.pdf; ComponentLink9URL: https://datasheet.octopart.com/CL31A106KAHNNNE-Samsung-Electro-Mechanics-datasheet-97040617.pdf; ComponentLink10Description: https://datasheet.octopart.com:443/CL31A106KAHNNNE-Samsung-datasheet-8330430.pdf?src-supplier=TME; ComponentLink10URL: https://datasheet.octopart.com:443/CL31A106KAHNNNE-Samsung-datasheet-8330430.pdf?src-supplier=TME; ComponentLink11Description: https://datasheet.octopart.com:443/CL31A106KAHNNNE-Samsung-datasheet-49908438.pdf?src-supplier=TME; ComponentLink11URL: https://datasheet.octopart.com:443/CL31A106KAHNNNE-Samsung-datasheet-49908438.pdf?src-supplier=TME; ComponentLink12Description: https://datasheet.octopart.com:443/CL31A106KAHNNNE-Samsung-datasheet-59220082.pdf?src-supplier=TME; ComponentLink12URL: https://datasheet.octopart.com:443/CL31A106KAHNNNE-Samsung-datasheet-59220082.pdf?src-supplier=TME; ComponentLink13Description: https://datasheet.octopart.com:443/CL31A106KAHNNNE-Samsung-datasheet-154853736.pdf?src-supplier=SOS+electronic; ComponentLink13URL: https://datasheet.octopart.com:443/CL31A106KAHNNNE-Samsung-datasheet-154853736.pdf?src-supplier=SOS+electronic; Contact Plating: Tin; Country of Origin: Mainland China, Philippines, South Korea; Depth: 1.6mm; Dielectric: X5R; Dielectric Material: Ceramic; Export Control Classification Number (ECCN) Code: EAR99; Harmonized Tariff Schedule (HTS) Code: 8532.24.00.20; Height: 1.8mm; Introduction Date: 2010-07-30; Lead Free: Lead Free; Length: 3.2mm; Library Name: My_Integrated_Library.IntLib; Lifecycle Status: Production; Manufacturer Part Number: CL31A106KAHNNNE; Material: Ceramic; Max Operating Temperature: 85°C; Min Operating Temperature: -55°C; Mounting Technology: Surface Mount; Number of Terminals: 2; Pricing: 1=0.18, 10=0.103, 50=0.073, 100=0.0638, 500=0.04824, 1000=0.04347 (USD); Radiation Hardening: No; REACH SVHC: Yes; RoHS: Compliant; Schedule B: 8532240020, 8532240020|8532240020|8532240020|8532240020|8532240020|8532240020|8532240020|8532240020|8532240020|8532240020, 8532240020|8532240020|8532240020|8532240020|8532240020|8532240020|8532240020|8532240020|8532240020|8532240020|8532240020, 8532240020|8532240020|8532240020|8532240020|8532240020|8532240020|8532240020|8532240020|8532240020|8532240020|8532240020|8532240020; Stock: 102055; Supplier: DigiKey; Supplier Part Number: 1276-1075-1-ND; Thickness: 1.8034mm; Voltage Rating: 25V; Voltage Rating (DC): 25V; Width: 1.6mm
C101-C106 = CL21A106KOQNNNE: 16V 10uF X5R 卤10% 0805 Multilayer Ceramic Capacitors MLCC - SMD/SMT ROHS; Manufacturer: Samsung Electro-Mechanics; Tolerance: 10%; Value: 10µF; Case Code (Imperial): 0805; Case Code (Metric): 2012; Case/Package: 0805; Category: Ceramic Capacitors; China RoHS: Compliant; Component Kind: Standard; ComponentLink1Description: https://datasheet.octopart.com:443/CL21A106KOQNNNE-Samsung-Electro-Mechanics-datasheet-136031799.pdf?src-supplier=IHS; ComponentLink1URL: https://datasheet.octopart.com:443/CL21A106KOQNNNE-Samsung-Electro-Mechanics-datasheet-136031799.pdf?src-supplier=IHS; ComponentLink2Description: https://datasheet.octopart.com/CL21A106KOQNNNE-Samsung-Electro-Mechanics-datasheet-8324245.pdf; ComponentLink2URL: https://datasheet.octopart.com/CL21A106KOQNNNE-Samsung-Electro-Mechanics-datasheet-8324245.pdf; ComponentLink3Description: https://datasheet.octopart.com/CL21A106KOQNNNE-Samsung-Electro-Mechanics-datasheet-97040617.pdf; ComponentLink3URL: https://datasheet.octopart.com/CL21A106KOQNNNE-Samsung-Electro-Mechanics-datasheet-97040617.pdf; ComponentLink4Description: https://datasheet.octopart.com/General-Multilayer-Ceramic-Capacitors-Samsung-datasheet-family-111.pdf; ComponentLink4URL: https://datasheet.octopart.com/General-Multilayer-Ceramic-Capacitors-Samsung-datasheet-family-111.pdf; ComponentLink5Description: https://datasheet.octopart.com:443/CL21A106KOQNNNE-Samsung-Electro-Mechanics-datasheet-130012977.pdf?src-supplier=Future+Electronics; ComponentLink5URL: https://datasheet.octopart.com:443/CL21A106KOQNNNE-Samsung-Electro-Mechanics-datasheet-130012977.pdf?src-supplier=Future+Electronics; ComponentLink6Description: https://datasheet.octopart.com:443/CL21A106KOQNNNE-Samsung-Electro-Mechanics-datasheet-82614846.pdf?src-supplier=Future+Electronics; ComponentLink6URL: https://datasheet.octopart.com:443/CL21A106KOQNNNE-Samsung-Electro-Mechanics-datasheet-82614846.pdf?src-supplier=Future+Electronics; ComponentLink7Description: https://datasheet.octopart.com:443/CL21A106KOQNNNE-Samsung-Electro-Mechanics-datasheet-81462122.pdf?src-supplier=Future+Electronics; ComponentLink7URL: https://datasheet.octopart.com:443/CL21A106KOQNNNE-Samsung-Electro-Mechanics-datasheet-81462122.pdf?src-supplier=Future+Electronics; ComponentLink8Description: https://datasheet.octopart.com:443/CL21A106KOQNNNE-Samsung-datasheet-48647542.pdf?src-supplier=Future+Electronics; ComponentLink8URL: https://datasheet.octopart.com:443/CL21A106KOQNNNE-Samsung-datasheet-48647542.pdf?src-supplier=Future+Electronics; ComponentLink9Description: https://datasheet.octopart.com:443/CL21A106KOQNNNE-Samsung-datasheet-26669228.pdf?src-supplier=Future+Electronics; ComponentLink9URL: https://datasheet.octopart.com:443/CL21A106KOQNNNE-Samsung-datasheet-26669228.pdf?src-supplier=Future+Electronics; ComponentLink10Description: https://datasheet.octopart.com:443/CL21A106KOQNNNE-Samsung-Electro-Mechanics-datasheet-149154152.pdf?src-supplier=Future+Electronics; ComponentLink10URL: https://datasheet.octopart.com:443/CL21A106KOQNNNE-Samsung-Electro-Mechanics-datasheet-149154152.pdf?src-supplier=Future+Electronics; ComponentLink11Description: https://datasheet.octopart.com:443/CL21A106KOQNNNE-Samsung-datasheet-22147434.pdf?src-supplier=TME; ComponentLink11URL: https://datasheet.octopart.com:443/CL21A106KOQNNNE-Samsung-datasheet-22147434.pdf?src-supplier=TME; ComponentLink12Description: http://sigma.octopart.com:80/rohs_certificate/General-Multilayer-Ceramic-Capacitors-Samsung-family-110.pdf?src-supplier=Compliance+Statements; ComponentLink12URL: http://sigma.octopart.com:80/rohs_certificate/General-Multilayer-Ceramic-Capacitors-Samsung-family-110.pdf?src-supplier=Compliance+Statements; ComponentLink13Description: http://sigma.octopart.com:80/rohs_statement/General-Multilayer-Ceramic-Capacitors-Samsung-family-132.pdf?src-supplier=Compliance+Statements; ComponentLink13URL: http://sigma.octopart.com:80/rohs_statement/General-Multilayer-Ceramic-Capacitors-Samsung-family-132.pdf?src-supplier=Compliance+Statements; ComponentLink14Description: http://sigma.octopart.com:80/reach_statement/General-Multilayer-Ceramic-Capacitors-Samsung-family-133.pdf?src-supplier=Compliance+Statements; ComponentLink14URL: http://sigma.octopart.com:80/reach_statement/General-Multilayer-Ceramic-Capacitors-Samsung-family-133.pdf?src-supplier=Compliance+Statements; Country of Origin: Mainland China, Philippines, South Korea; Depth: 1.25mm; Dielectric: X5R; Dielectric Material: Ceramic; Export Control Classification Number (ECCN) Code: EAR99; Harmonized Tariff Schedule (HTS) Code: 8532.24.00.20; Height: 1.4mm; Introduction Date: 2010-07-30; Lead Free: Lead Free; Length: 2mm; Library Name: My_Integrated_Library.IntLib; Lifecycle Status: Production; Manufacturer Part Number: CL21A106KOQNNNE; Material: Ceramic; Max Operating Temperature: 85°C; Min Operating Temperature: -55°C; Mounting Technology: Surface Mount; Number of Terminals: 2; Pricing: 1=0.1, 10=0.054, 50=0.0368, 100=0.0317, 500=0.02312, 1000=0.0205 (USD); Radiation Hardening: No; REACH SVHC: Yes; RoHS: Compliant; Schedule B: 8532240020, 8532240020|8532240020|8532240020|8532240020|8532240020|8532240020|8532240020|8532240020|8532240020|8532240020|8532240020|8532240020|8532240020|8532240020|8532240020|8532240020|8532240020|8532240020|8532240020|8532240020|8532240020|8532240020|8532240020|85; Stock: 1759427; Supplier: DigiKey; Supplier Part Number: 1276-1096-1-ND; Thickness: 1.397mm; Voltage: 16V; Voltage Rating: 16V; Voltage Rating (DC): 16V; Width: 1.25mm
C107-C112 = CL31B475KAHNNNE: 25V 4.7uF X7R 卤10% 1206 Multilayer Ceramic Capacitors MLCC - SMD/SMT ROHS; Manufacturer: Samsung Electro-Mechanics; Tolerance: 10%; Value: 4.7µF; Case Code (Imperial): 1206; Case Code (Metric): 3216; Case/Package: 1206; Category: Ceramic Capacitors; China RoHS: Compliant; Component Kind: Standard; ComponentLink1Description: https://datasheet.octopart.com:443/CL31B475KAHNNNE-Samsung-Electro-Mechanics-datasheet-137745100.pdf?src-supplier=IHS; ComponentLink1URL: https://datasheet.octopart.com:443/CL31B475KAHNNNE-Samsung-Electro-Mechanics-datasheet-137745100.pdf?src-supplier=IHS; ComponentLink2Description: https://datasheet.octopart.com:443/CL31B475KAHNNNE-Samsung-Electro-Mechanics-datasheet-81462525.pdf?src-supplier=SOS+electronic; ComponentLink2URL: https://datasheet.octopart.com:443/CL31B475KAHNNNE-Samsung-Electro-Mechanics-datasheet-81462525.pdf?src-supplier=SOS+electronic; ComponentLink3Description: https://datasheet.octopart.com:443/CL31B475KAHNNNE-Samsung-datasheet-152734778.pdf?src-supplier=SOS+electronic; ComponentLink3URL: https://datasheet.octopart.com:443/CL31B475KAHNNNE-Samsung-datasheet-152734778.pdf?src-supplier=SOS+electronic; ComponentLink4Description: https://datasheet.octopart.com/CL31B475KAHNNNE-Samsung-Electro-Mechanics-datasheet-8324245.pdf; ComponentLink4URL: https://datasheet.octopart.com/CL31B475KAHNNNE-Samsung-Electro-Mechanics-datasheet-8324245.pdf; ComponentLink5Description: https://datasheet.octopart.com/CL31B475KAHNNNE-Samsung-Electro-Mechanics-datasheet-97040617.pdf; ComponentLink5URL: https://datasheet.octopart.com/CL31B475KAHNNNE-Samsung-Electro-Mechanics-datasheet-97040617.pdf; ComponentLink6Description: https://datasheet.octopart.com/General-Multilayer-Ceramic-Capacitors-Samsung-datasheet-family-111.pdf; ComponentLink6URL: https://datasheet.octopart.com/General-Multilayer-Ceramic-Capacitors-Samsung-datasheet-family-111.pdf; ComponentLink7Description: https://datasheet.octopart.com:443/CL31B475KAHNNNE-Samsung-Electro-Mechanics-datasheet-149047451.pdf?src-supplier=Future+Electronics; ComponentLink7URL: https://datasheet.octopart.com:443/CL31B475KAHNNNE-Samsung-Electro-Mechanics-datasheet-149047451.pdf?src-supplier=Future+Electronics; ComponentLink8Description: https://datasheet.octopart.com:443/CL31B475KAHNNNE-Samsung-Electro-Mechanics-datasheet-116891130.pdf?src-supplier=Future+Electronics; ComponentLink8URL: https://datasheet.octopart.com:443/CL31B475KAHNNNE-Samsung-Electro-Mechanics-datasheet-116891130.pdf?src-supplier=Future+Electronics; ComponentLink9Description: https://datasheet.octopart.com:443/CL31B475KAHNNNE-Samsung-datasheet-46750742.pdf?src-supplier=Future+Electronics; ComponentLink9URL: https://datasheet.octopart.com:443/CL31B475KAHNNNE-Samsung-datasheet-46750742.pdf?src-supplier=Future+Electronics; ComponentLink10Description: https://datasheet.octopart.com:443/CL31B475KAHNNNE-Samsung-datasheet-26673814.pdf?src-supplier=Future+Electronics; ComponentLink10URL: https://datasheet.octopart.com:443/CL31B475KAHNNNE-Samsung-datasheet-26673814.pdf?src-supplier=Future+Electronics; ComponentLink11Description: https://datasheet.octopart.com:443/CL31B475KAHNNNE-Samsung-datasheet-59220082.pdf?src-supplier=TME; ComponentLink11URL: https://datasheet.octopart.com:443/CL31B475KAHNNNE-Samsung-datasheet-59220082.pdf?src-supplier=TME; ComponentLink12Description: http://sigma.octopart.com:80/rohs_certificate/General-Multilayer-Ceramic-Capacitors-Samsung-family-110.pdf?src-supplier=Compliance+Statements; ComponentLink12URL: http://sigma.octopart.com:80/rohs_certificate/General-Multilayer-Ceramic-Capacitors-Samsung-family-110.pdf?src-supplier=Compliance+Statements; ComponentLink13Description: http://sigma.octopart.com:80/rohs_statement/General-Multilayer-Ceramic-Capacitors-Samsung-family-132.pdf?src-supplier=Compliance+Statements; ComponentLink13URL: http://sigma.octopart.com:80/rohs_statement/General-Multilayer-Ceramic-Capacitors-Samsung-family-132.pdf?src-supplier=Compliance+Statements; ComponentLink14Description: http://sigma.octopart.com:80/reach_statement/General-Multilayer-Ceramic-Capacitors-Samsung-family-133.pdf?src-supplier=Compliance+Statements; ComponentLink14URL: http://sigma.octopart.com:80/reach_statement/General-Multilayer-Ceramic-Capacitors-Samsung-family-133.pdf?src-supplier=Compliance+Statements; Contact Plating: Tin; Depth: 1.6mm; Dielectric: X7R; Dielectric Material: Ceramic; Height: 1.8mm; Lead Free: Lead Free; Length: 3.2mm; Library Name: My_Integrated_Library.IntLib; Lifecycle Status: Production; Manufacturer Part Number: CL31B475KAHNNNE; Material: Ceramic; Max Operating Temperature: 125°C; Min Operating Temperature: -55°C; Mounting Technology: Surface Mount; Number of Terminals: 2; Pricing: 1=0.18, 10=0.1, 50=0.0704, 100=0.0614, 500=0.04638, 1000=0.04176 (USD); Radiation Hardening: No; REACH SVHC: Yes; RoHS: Compliant; Schedule B: 8532240020, 8532240020|8532240020, 8532240020|8532240020|8532240020, 8532240020|8532240020|8532240020|8532240020; Stock: 204549; Supplier: DigiKey; Supplier Part Number: 1276-1055-1-ND; Thickness: 1.8034mm; Voltage Rating: 25V; Voltage Rating (DC): 25V; Width: 1.6mm
C113-C118 = CL21A475KLCLQNC: 35V 4.7uF X5R 卤10% 0805 Multilayer Ceramic Capacitors MLCC - SMD/SMT ROHS; Manufacturer: Samsung Electro-Mechanics; Tolerance: 10%; Value: 4.7µF; Case Code (Imperial): 0805; Case Code (Metric): 2012; Case/Package: 0805; Category: Ceramic Capacitors; China RoHS: Compliant; Component Kind: Standard; ComponentLink1Description: https://datasheet.octopart.com:443/CL21A475KLCLQNC-Samsung-Electro-Mechanics-datasheet-136031818.pdf?src-supplier=IHS; ComponentLink1URL: https://datasheet.octopart.com:443/CL21A475KLCLQNC-Samsung-Electro-Mechanics-datasheet-136031818.pdf?src-supplier=IHS; ComponentLink2Description: https://datasheet.octopart.com:443/CL21A475KLCLQNC-Samsung-Electro-Mechanics-datasheet-141347552.pdf?src-supplier=Samsung+SCT; ComponentLink2URL: https://datasheet.octopart.com:443/CL21A475KLCLQNC-Samsung-Electro-Mechanics-datasheet-141347552.pdf?src-supplier=Samsung+SCT; ComponentLink3Description: https://datasheet.octopart.com:443/CL21A475KLCLQNC-Samsung-Electro-Mechanics-datasheet-121364586.pdf?src-supplier=Future+Electronics; ComponentLink3URL: https://datasheet.octopart.com:443/CL21A475KLCLQNC-Samsung-Electro-Mechanics-datasheet-121364586.pdf?src-supplier=Future+Electronics; ComponentLink4Description: https://datasheet.octopart.com:443/CL21A475KLCLQNC-Samsung-Electro-Mechanics-datasheet-97040617.pdf?src-supplier=Future+Electronics; ComponentLink4URL: https://datasheet.octopart.com:443/CL21A475KLCLQNC-Samsung-Electro-Mechanics-datasheet-97040617.pdf?src-supplier=Future+Electronics; ComponentLink5Description: https://datasheet.octopart.com:443/CL21A475KLCLQNC-Samsung-Electro-Mechanics-datasheet-149136985.pdf?src-supplier=Future+Electronics; ComponentLink5URL: https://datasheet.octopart.com:443/CL21A475KLCLQNC-Samsung-Electro-Mechanics-datasheet-149136985.pdf?src-supplier=Future+Electronics; Country of Origin: Mainland China, Philippines, South Korea; Dielectric Material: Ceramic; Export Control Classification Number (ECCN) Code: EAR99; Harmonized Tariff Schedule (HTS) Code: 8532.24.00.20; Height: 850µm; Introduction Date: 2017-07-19; Length: 2mm; Library Name: MySchLib.SchLib; Lifecycle Status: Production; Manufacturer Part Number: CL21A475KLCLQNC; Material: Ceramic; Max Operating Temperature: 85°C; Min Operating Temperature: -55°C; Mounting Technology: Surface Mount; Number of Terminals: 2; Pricing: 1=0.18, 10=0.099, 50=0.0702, 100=0.0613, 500=0.04628, 1000=0.04165 (USD); REACH SVHC: Yes; RoHS: Compliant; Schedule B: 8532240020, 8532240020|8532240020|8532240020|8532240020|8532240020|8532240020|8532240020|8532240020|8532240020|8532240020|8532240020|8532240020|8532240020|8532240020|8532240020|8532240020|8532240020|8532240020|8532240020|8532240020|8532240020|8532240020|8532240020|85; Stock: 242708; Supplier: DigiKey; Supplier Part Number: 1276-6722-1-ND; Thickness: 939.8µm; Voltage Rating (DC): 35V; Width: 1.25mm
J139-J204 = FTS-102-01-L-S: CONN HEADER VERT 2POS 1.27MM; Manufacturer: Samtec; Manufacturer Lifecycle Status: PRODUCTION; Gender: Male; Number of Contacts: 2; Category: Headers and Wire Housings; Component Kind: Standard; ComponentLink1Description: https://sigma.octopart.com:443/165596534/technical_drawing/Samtec-FTS-102-01-L-S.pdf?src-supplier=JRH+Electronics; ComponentLink1URL: https://sigma.octopart.com:443/165596534/technical_drawing/Samtec-FTS-102-01-L-S.pdf?src-supplier=JRH+Electronics; ComponentLink2Description: https://datasheet.octopart.com:443/FTS-102-01-L-S-Samtec-datasheet-164323441.pdf?src-supplier=Upverter; ComponentLink2URL: https://datasheet.octopart.com:443/FTS-102-01-L-S-Samtec-datasheet-164323441.pdf?src-supplier=Upverter; ComponentLink3Description: https://sigma.octopart.com:443/97029285/technical_drawing/Samtec-FTS-102-01-L-S.pdf?src-supplier=Newark; ComponentLink3URL: https://sigma.octopart.com:443/97029285/technical_drawing/Samtec-FTS-102-01-L-S.pdf?src-supplier=Newark; ComponentLink4Description: https://datasheet.octopart.com:443/FTS-102-01-L-S-Samtec-datasheet-97029283.pdf?src-supplier=Samtec; ComponentLink4URL: https://datasheet.octopart.com:443/FTS-102-01-L-S-Samtec-datasheet-97029283.pdf?src-supplier=Samtec; ComponentLink5Description: https://datasheet.octopart.com:443/FTS-102-01-L-S-Samtec-datasheet-118493358.pdf?src-supplier=Electro+Sonic; ComponentLink5URL: https://datasheet.octopart.com:443/FTS-102-01-L-S-Samtec-datasheet-118493358.pdf?src-supplier=Electro+Sonic; ComponentLink6Description: https://datasheet.octopart.com:443/FTS-102-01-L-S-Samtec-datasheet-176663378.pdf?src-supplier=Toby+Electronics; ComponentLink6URL: https://datasheet.octopart.com:443/FTS-102-01-L-S-Samtec-datasheet-176663378.pdf?src-supplier=Toby+Electronics; ComponentLink7Description: https://datasheet.octopart.com:443/FTS-102-01-L-S-Samtec-datasheet-116535195.pdf?src-supplier=Toby+Electronics; ComponentLink7URL: https://datasheet.octopart.com:443/FTS-102-01-L-S-Samtec-datasheet-116535195.pdf?src-supplier=Toby+Electronics; ComponentLink8Description: https://datasheet.octopart.com:443/FTS-102-01-L-S-Samtec-datasheet-136395376.pdf?src-supplier=Toby+Electronics; ComponentLink8URL: https://datasheet.octopart.com:443/FTS-102-01-L-S-Samtec-datasheet-136395376.pdf?src-supplier=Toby+Electronics; ComponentLink9Description: https://datasheet.octopart.com:443/FTS-102-01-L-S-Samtec-datasheet-151470456.pdf?src-supplier=Sager+Electronics; ComponentLink9URL: https://datasheet.octopart.com:443/FTS-102-01-L-S-Samtec-datasheet-151470456.pdf?src-supplier=Sager+Electronics; Contact Material: Gold, Nickel; Contact Plating: Gold; Height: 4.17mm; Housing Color: Black; Housing Material: Polymer; Length: 2.54mm; Library Name: Schlib.SchLib; Lifecycle Status: Production; Manufacturer Part Number: FTS-102-01-L-S; Max Operating Temperature: 125°C; Min Operating Temperature: -55°C; Number of Rows: 2; Orientation: Straight; Packaging: Bulk; Pitch: 1.27mm; Pricing: 1=0.57, 50=0.496, 100=0.42, 500=0.35, 1000=0.299, 2000=0.244, 5000=0.24223 (USD); Radiation Hardening: No; RoHS: Compliant; Stock: 1509; Supplier: DigiKey; Supplier Part Number: FTS-102-01-L-S-ND; Termination: Solder
